# Altium schematic sheet ControllerRPi.SchDoc(ControllerRPi)
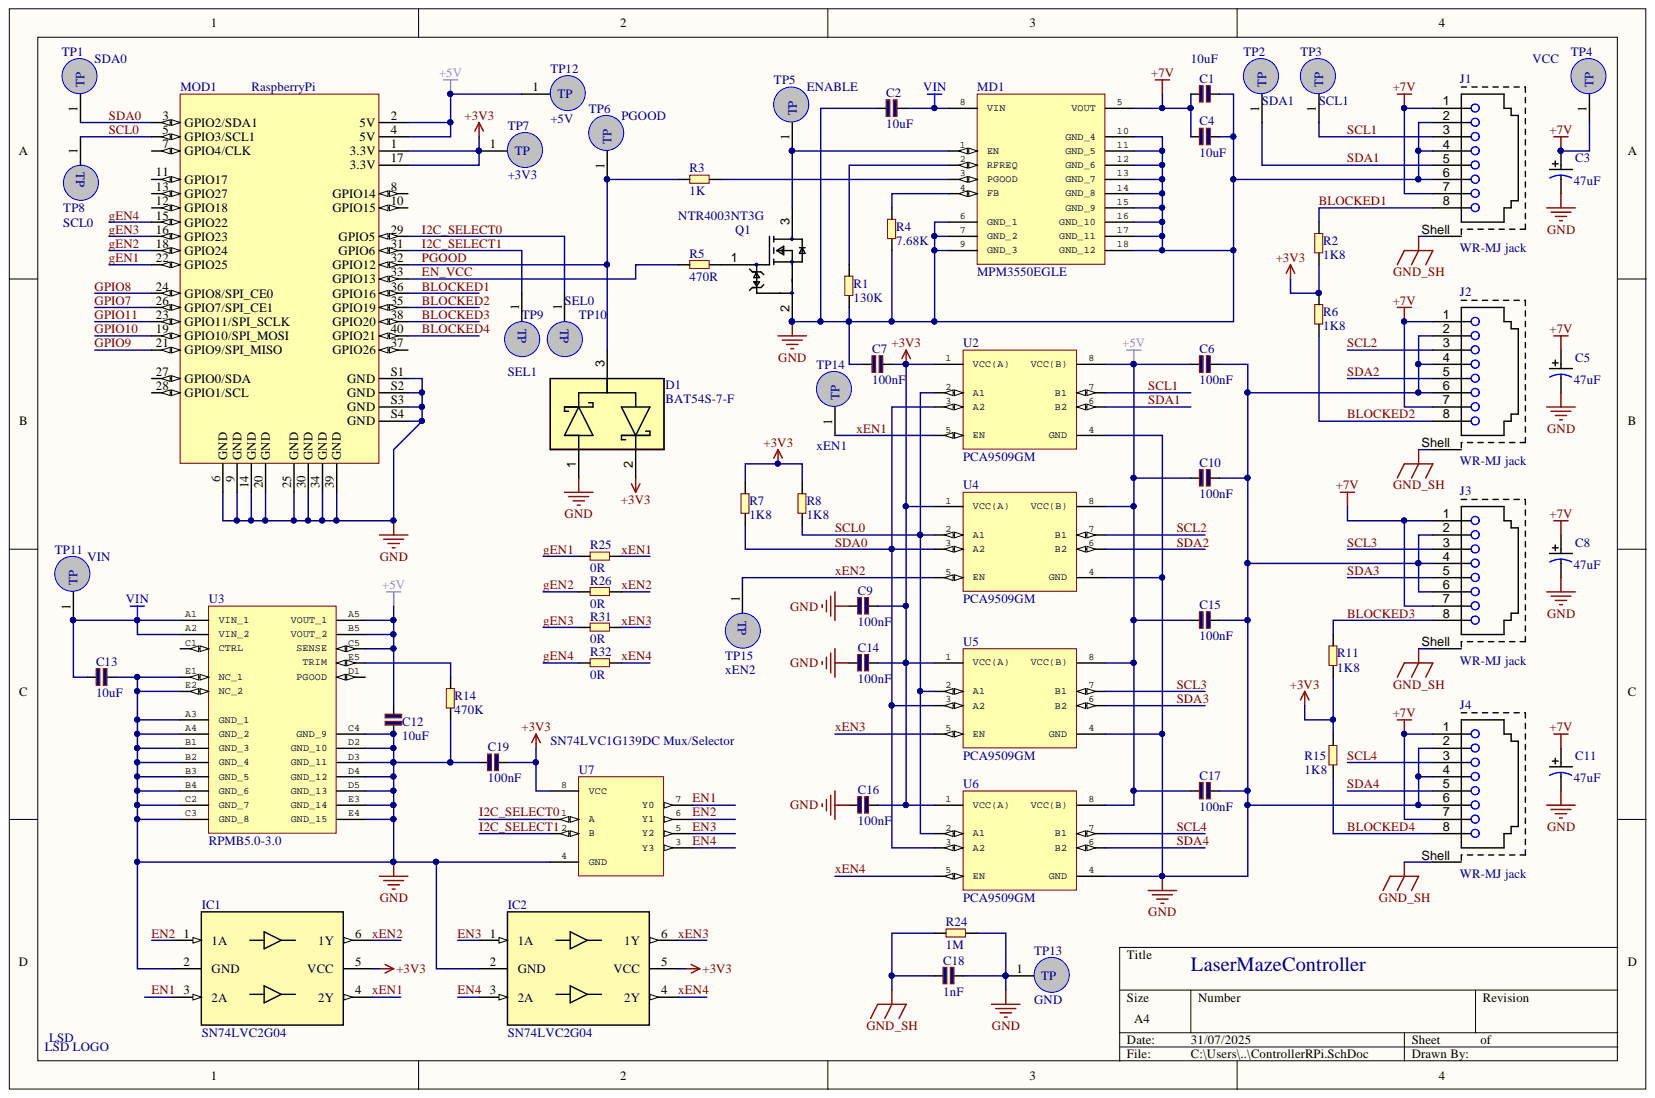
[diagram p0: ControllerRPi.SchDoc]

## Parts
1  LSD LOGO  [LSD Logo]
C1  10uF  [1210]
C2  10uF  [1210]
C3  47uF  [CAPAE7067X55L]
C4  10uF  [1210]
C5  47uF  [CAPAE7067X55L]
C6  100nF  [0805]
C7  100nF  [0805]
C8  47uF  [CAPAE7067X55L]
C9  100nF  [0805]
C10  100nF  [0805]
C11  47uF  [CAPAE7067X55L]
C12  10uF  [1210]
C13  10uF  [1210]
C14  100nF  [0805]
C15  100nF  [0805]
C16  100nF  [0805]
C17  100nF  [0805]
C18  1nF  [0805]
C19  100nF  [0805]
D1  BAT54S-7-F  [SOT23 NUM]
IC1  SN74LVC2G04  [SC-70]
IC2  SN74LVC2G04  [SC-70]
J1  WR-MJ jack  [WR_MJ_RJ45_Horiz]
J2  WR-MJ jack  [WR_MJ_RJ45_Horiz]
J3  WR-MJ jack  [WR_MJ_RJ45_Horiz]
J4  WR-MJ jack  [WR_MJ_RJ45_Horiz]
MD1  MPM3550EGLE  [MPM3550EGLE]
MOD1  RaspberryPi  [RPi_min]
Q1  NTR4003NT3G  [SOT23 NUM]
R1  130K  [0805]
R2  1K8  [0805]
R3  1K  [0805]
R4  7.68K  [0805]
R5  470R  [0805]
R6  1K8  [0805]
R7  1K8  [0805]
R8  1K8  [0805]
R11  1K8  [0805]
R14  470K  [0805]
R15  1K8  [0805]
R24  1M  [0805]
R25  0R  [0805]
R26  0R  [0805]
R31  0R  [0805]
R32  0R  [0805]
TP1  SDA0  [Testpoint]
TP2  SDA1  [Testpoint]
TP3  SCL1  [Testpoint]
TP4  VCC  [Testpoint]
TP5  ENABLE  [Testpoint]
TP6  PGOOD  [Testpoint]
TP7  +3V3  [Testpoint]
TP8  SCL0  [Testpoint]
TP9  SEL1  [Testpoint]
TP10  SEL0  [Testpoint]
TP11  VIN  [Testpoint]
TP12  +5V  [Testpoint]
TP13  GND  [Testpoint]
TP14  xEN1  [Testpoint]
TP15  xEN2  [Testpoint]
U2  PCA9509GM  [TSSOP8]
U3  RPMB5.0-3.0  [RPMB5.0-3]
U4  PCA9509GM  [TSSOP8]
U5  PCA9509GM  [TSSOP8]
U6  PCA9509GM  [TSSOP8]
U7  SN74LVC1G139DC Mux/Selector  [SOP65P400X130-8N]

## Nets
+3V3: C7.2 C9.2 C14.2 C16.2 C19.2 D1.2 IC1.5(VCC) IC2.5(VCC) MOD1.1(3.3V) MOD1.17(3.3V) R2.1 R6.2 R7.1 R8.1 R11.1 R15.2 TP7.1 U2.1(VCC(A)) U4.1(VCC(A)) U5.1(VCC(A)) U6.1(VCC(A)) U7.8(VCC)
+5V: C6.2 C10.1 C12.2 C15.1 C17.1 MOD1.2(5V) MOD1.4(5V) TP12.1 U2.8(VCC(B)) U3.A5(VOUT_1) U3.B5(VOUT_2) U3.C5(SENSE) U4.8(VCC(B)) U5.8(VCC(B)) U6.8(VCC(B))
+7V: C1.1 C3.1 C4.1 C5.1 C8.1 C11.1 J1.1 J1.7 J2.1 J2.7 J3.1 J3.7 J4.1 J4.7 MD1.5(VOUT) TP4.1
GND: C1.2 C2.1 C3.2 C4.2 C5.2 C6.1 C7.1 C8.2 C9.1 C10.2 C11.2 C12.1 C13.1 C14.1 C15.2 C16.1 C17.2 C18.2 C19.1 D1.1 IC1.2(GND) IC2.2(GND) J1.2 J1.4 J1.6 J2.2 J2.4 J2.6 J3.2 J3.4 J3.6 J4.2 J4.4 J4.6 MD1.6(GND_1) MD1.7(GND_2) MD1.9(GND_3) MD1.10(GND_4) MD1.11(GND_5) MD1.12(GND_6) MD1.13(GND_7) MD1.14(GND_8) MD1.15(GND_9) MD1.16(GND_10) MD1.17(GND_11) MD1.18(GND_12) MOD1.6(GND) MOD1.9(GND) MOD1.14(GND) MOD1.20(GND) MOD1.25(GND) MOD1.30(GND) MOD1.34(GND) MOD1.39(GND) MOD1.S1(GND) MOD1.S2(GND) MOD1.S3(GND) MOD1.S4(GND) Q1.2 R1.2 R4.2 R14.2 R24.1 TP13.1 U2.4(GND) U3.A3(GND_1) U3.A4(GND_2) U3.B1(GND_3) U3.B2(GND_4) U3.B3(GND_5) U3.B4(GND_6) U3.C2(GND_7) U3.C3(GND_8) U3.C4(GND_9) U3.D2(GND_10) U3.D3(GND_11) U3.D4(GND_12) U3.D5(GND_13) U3.E1(NC_1) U3.E2(NC_2) U3.E3(GND_14) U3.E4(GND_15) U4.4(GND) U5.4(GND) U6.4(GND) U7.4(GND)
GND_SH: C18.1 J1.Shell J2.Shell J3.Shell J4.Shell R24.2
VIN: C2.2 C13.2 MD1.8(VIN) TP11.1 U3.A1(VIN_1) U3.A2(VIN_2)
BLOCKED1: J1.8 MOD1.36(GPIO16) R2.2
BLOCKED2: J2.8 MOD1.35(GPIO19) R6.1
BLOCKED3: J3.8 MOD1.38(GPIO20) R11.2
BLOCKED4: J4.8 MOD1.40(GPIO21) R15.1
EN1: IC1.3(2A) U7.7(Y0)
EN2: IC1.1(1A) U7.6(Y1)
EN3: IC2.1(1A) U7.5(Y2)
EN4: IC2.3(2A) U7.3(Y3)
EN_VCC: MOD1.33(GPIO13) R5.2
gEN1: MOD1.22(GPIO25) R25.2
gEN2: MOD1.18(GPIO24) R26.2
gEN3: MOD1.16(GPIO23) R31.2
gEN4: MOD1.15(GPIO22) R32.2
GPIO7: MOD1.26(GPIO7/SPI_CE1)
GPIO8: MOD1.24(GPIO8/SPI_CE0)
GPIO9: MOD1.21(GPIO9/SPI_MISO)
GPIO10: MOD1.19(GPIO10/SPI_MOSI)
GPIO11: MOD1.23(GPIO11/SPI_SCLK)
I2C_SELECT0: MOD1.29(GPIO5) TP10.1 U7.1(A)
I2C_SELECT1: MOD1.31(GPIO6) TP9.1 U7.2(B)
PGOOD: D1.3 MOD1.32(GPIO12) R3.2 TP6.1
SCL0: MOD1.5(GPIO3/SCL1) R8.2 TP8.1 U2.2(A1) U4.2(A1) U5.2(A1) U6.2(A1)
SCL1: J1.3 TP3.1 U2.7(B1)
SCL2: J2.3 U4.7(B1)
SCL3: J3.3 U5.7(B1)
SCL4: J4.3 U6.7(B1)
SDA0: MOD1.3(GPIO2/SDA1) R7.2 TP1.1 U2.3(A2) U4.3(A2) U5.3(A2) U6.3(A2)
SDA1: J1.5 TP2.1 U2.6(B2)
SDA2: J2.5 U4.6(B2)
SDA3: J3.5 U5.6(B2)
SDA4: J4.5 U6.6(B2)
xEN1: IC1.4(2Y) R25.1 TP14.1 U2.5(EN)
xEN2: IC1.6(1Y) R26.1 TP15.1 U4.5(EN)
xEN3: IC2.6(1Y) R31.1 U5.5(EN)
xEN4: IC2.4(2Y) R32.1 U6.5(EN)
NetMD1_1: MD1.1(EN) Q1.3 TP5.1
NetMD1_2: MD1.2(RFREQ) R1.1
NetMD1_3: MD1.3(PGOOD) R3.1
NetMD1_4: MD1.4(FB) R4.1
NetQ1_1: Q1.1 R5.1
NetR14_1: R14.1 U3.E5(TRIM)

Not connected: MOD1 7(GPIO4/CLK) 8(GPIO14) 10(GPIO15) 11(GPIO17) 12(GPIO18) 13(GPIO27) 27(GPIO0/SDA) 28(GPIO1/SCL) 37(GPIO26); U3 C1(CTRL) D1(PGOOD)

## Library data of the parts
C1 C2 C4 C6 C7 C9 C10 C12-C19 = CAP: SMT ceramic capacitor; Component Kind: Standard; Library Name: RusconX.LIB
C3 C5 C8 C11 = CAP-ELECTRO: 6.3 x 5.4 mm case; Component Kind: Standard; Library Name: RusconX.LIB
D1 = BAT54S: BAT54S Dual diode SOT-23 num; Component Kind: Standard; Library Name: RusconX.LIB
IC1 IC2 = SN74LVC2G04: Dual buffer invertor - SC-70; Component Kind: Standard; Library Name: RusconX.LIB
J1-J4 = RJ45: WR-MJ Rear Post Modular Jack  Vertical; Component Kind: Standard; Library Name: RusconX.LIB
MOD1 = Rasb: Raspberry Pi Model B 3; Component Kind: Standard; Library Name: RusconX.LIB
Q1 = MOSFET-2: MOSFET-N - numbered; Component Kind: Standard; Library Name: RusconX.LIB
R1-R8 R11 R14 R15 R24-R26 R31 R32 = RES: Resistor; Text Field2: IRH; Text Field1: IRC; Component Kind: Standard; Library Name: RusconX.LIB
TP1-TP15 = TestPoint: Description: Testpoint; Component Kind: Standard; Library Name: RusconX.LIB
U2 U4-U6 = PCA9509GM: IO Expander; Component Kind: Standard; Library Name: RusconX.LIB
U3 = RPMB-3.0: 3A SMD switching regulator; Component Kind: Standard; Library Name: RusconX.LIB
1 = LSD LOGO: Component Kind: Standard; Library Name: RusconX.LIB
MD1 = MPM3550EGLE: Component Kind: Standard; Library Name: RusconX.LIB
U7 = SN74LVC1G139DC Mux/Selector: Component Kind: Standard; Library Name: RusconX.LIB
